# Altium schematic sheet StepGenerator.SchDoc(StepGenerator)
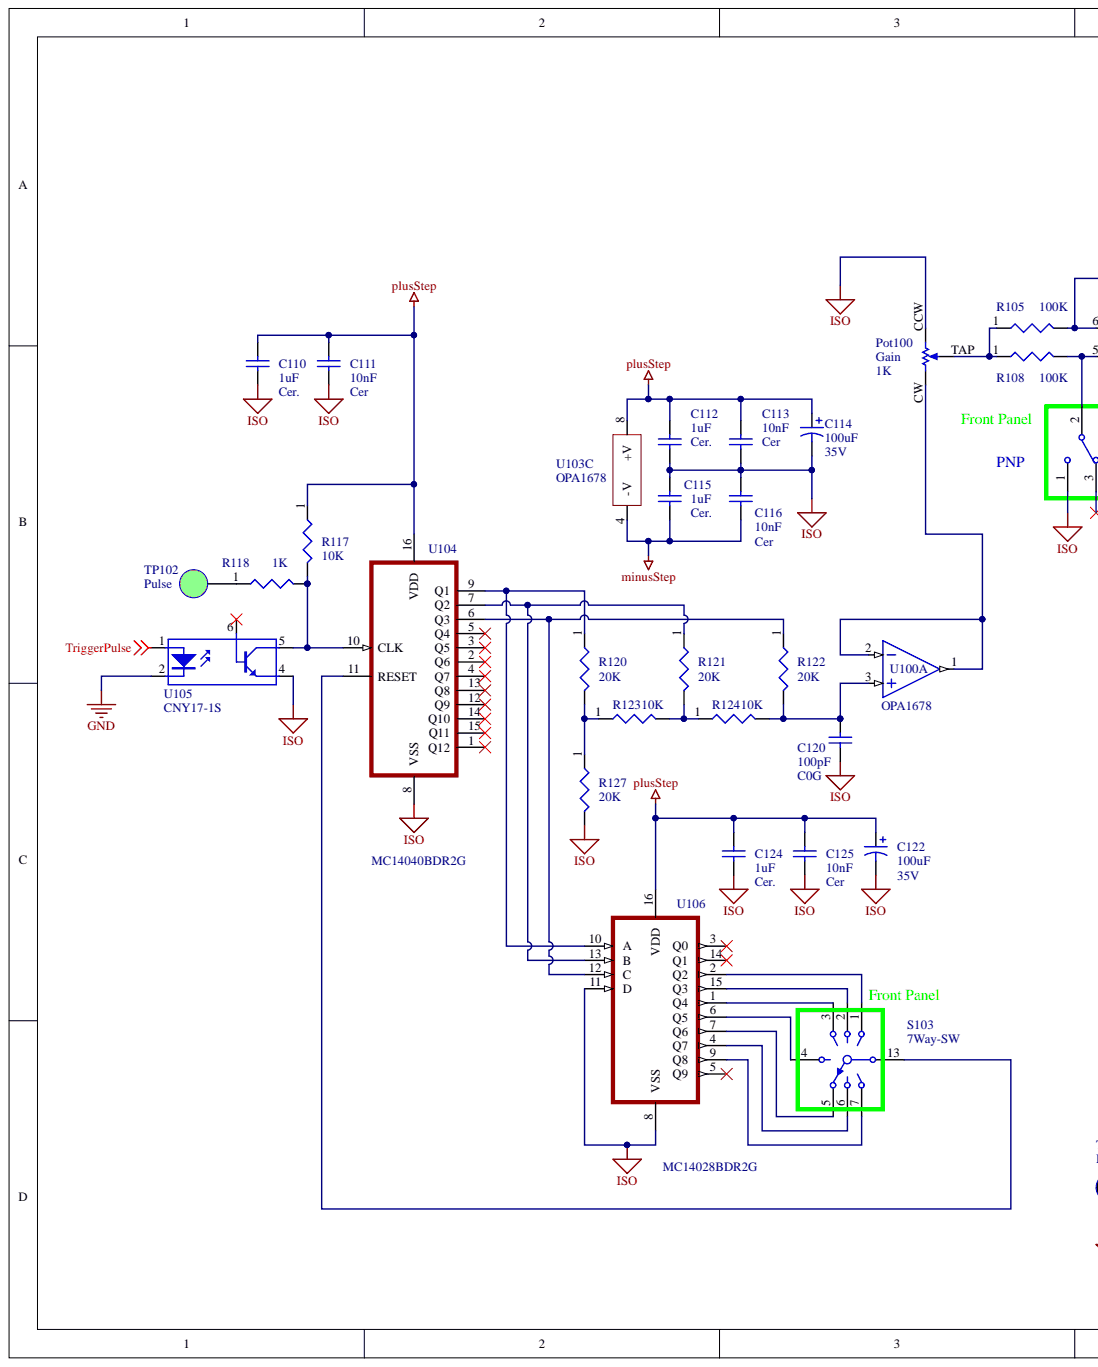
[diagram p0: StepGenerator.SchDoc, left]
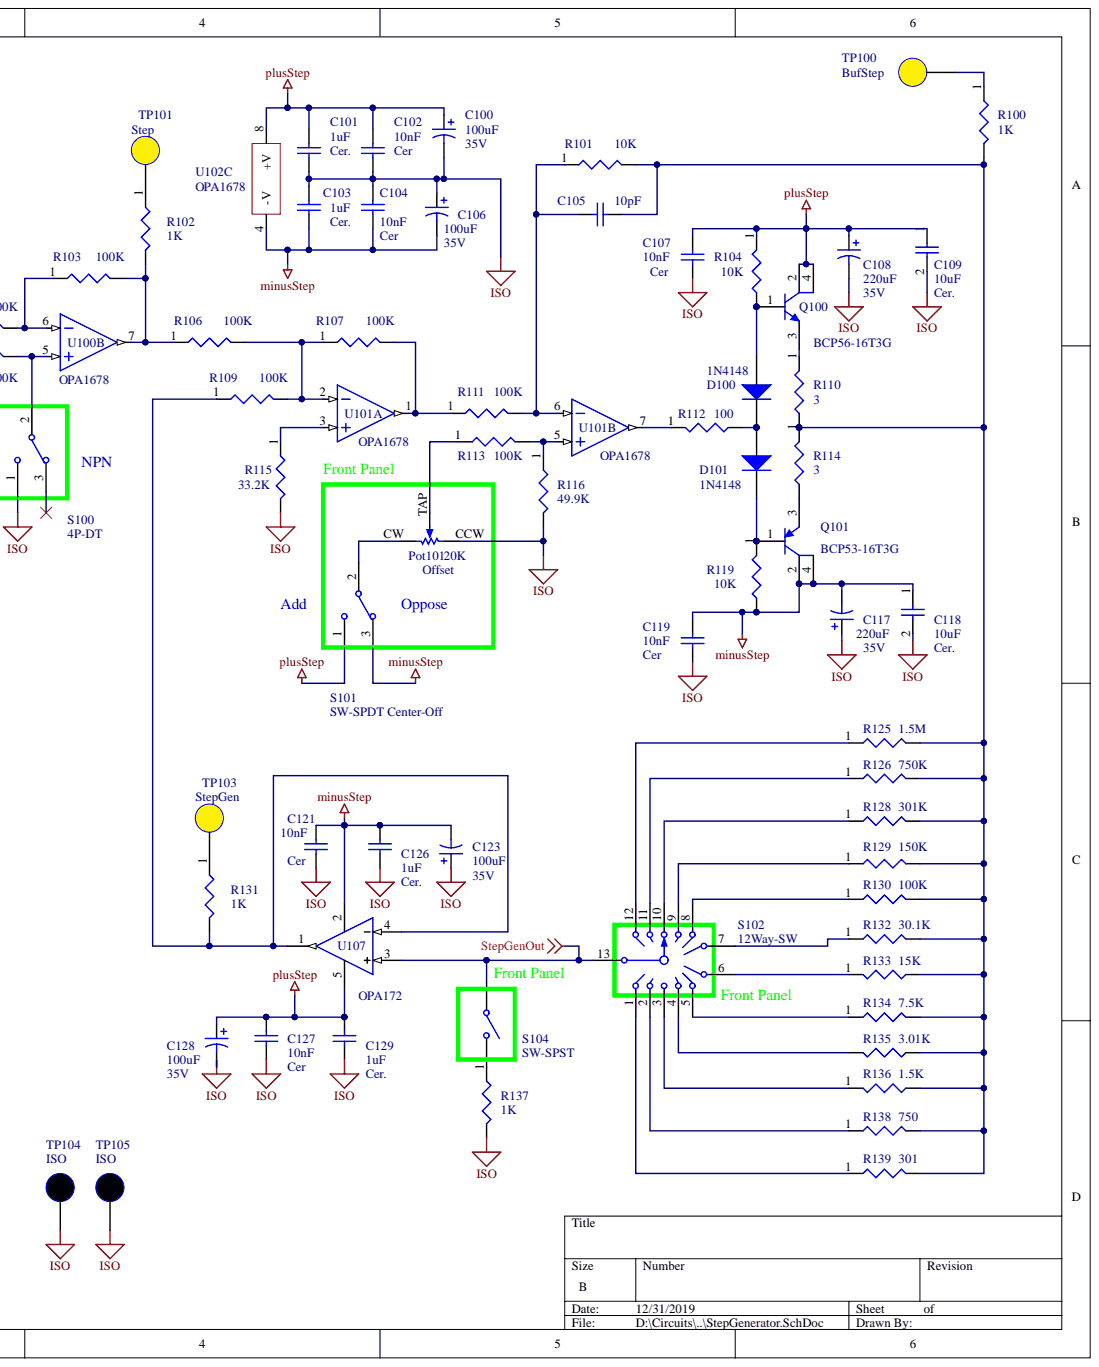
[diagram p1: StepGenerator.SchDoc, right]

## Parts
C100  100uF  Voltage Rating: 35V  [CAPPR2.5-6.3X10]
C101  value 1uF  Dielectric Material: Cer.  [CAPC3216M]  (hidden comment: Cap)
C102  10nF  Dielectric Material: Cer  [CAPC3216M]
C103  value 1uF  Dielectric Material: Cer.  [CAPC3216M]  (hidden comment: Cap)
C104  10nF  Dielectric Material: Cer  [CAPC3216M]
C105  value 10pF  [CAPC3216M]  (hidden comment: Cap)
C106  100uF  Voltage Rating: 35V  [CAPPR2.5-6.3X10]
C107  10nF  Dielectric Material: Cer  [CAPC3216M]
C108  220uF  Voltage Rating: 35V  [CAPPR3.5-8x12]
C109  value 10uF  Dielectric Material: Cer.  [CAPC3216M]  (hidden comment: Cap)
C110  value 1uF  Dielectric Material: Cer.  [CAPC3216M]  (hidden comment: Cap)
C111  10nF  Dielectric Material: Cer  [CAPC3216M]
C112  value 1uF  Dielectric Material: Cer.  [CAPC3216M]  (hidden comment: Cap)
C113  10nF  Dielectric Material: Cer  [CAPC3216M]
C114  100uF  Voltage Rating: 35V  [CAPPR2.5-6.3X10]
C115  value 1uF  Dielectric Material: Cer.  [CAPC3216M]  (hidden comment: Cap)
C116  10nF  Dielectric Material: Cer  [CAPC3216M]
C117  220uF  Voltage Rating: 35V  [CAPPR3.5-8x12]
C118  value 10uF  Dielectric Material: Cer.  [CAPC3216M]  (hidden comment: Cap)
C119  10nF  Dielectric Material: Cer  [CAPC3216M]
C120  value 100pF  Temperature Coefficient: C0G  [CAPC3216M]  (hidden comment: Cap)
C121  10nF  Dielectric Material: Cer  [CAPC3216M]
C122  100uF  Voltage Rating: 35V  [CAPPR2.5-6.3X10]
C123  100uF  Voltage Rating: 35V  [CAPPR2.5-6.3X10]
C124  value 1uF  Dielectric Material: Cer.  [CAPC3216M]  (hidden comment: Cap)
C125  10nF  Dielectric Material: Cer  [CAPC3216M]
C126  value 1uF  Dielectric Material: Cer.  [CAPC3216M]  (hidden comment: Cap)
C127  10nF  Dielectric Material: Cer  [CAPC3216M]
C128  100uF  Voltage Rating: 35V  [CAPPR2.5-6.3X10]
C129  value 1uF  Dielectric Material: Cer.  [CAPC3216M]  (hidden comment: Cap)
D100  1N4148  [SOD123]
D101  1N4148  [SOD123]
Pot100  Gain  value 1K  [3306P-CCW]
Pot101  Offset  value 20K  [Off-PCB]
Q100  Manufacturer Part Number: BCP56-16T3G  [SOT223-4M]  (hidden comment: NPN-2C)
Q101  Manufacturer Part Number: BCP53-16T3G  [SOT223-4M]  (hidden comment: PNP-2C)
R100  1K  [RESC3216M]
R101  value 10K  [RESC3216M]  (hidden comment: 20K)
R102  1K  [RESC3216M]
R103  value 100K  [RESC3216M]  (hidden comment: 20K)
R104  10K  [RESC3216M]
R105  100k  [RESC3216M]
R106  100k  [RESC3216M]
R107  100k  [RESC3216M]
R108  100k  [RESC3216M]
R109  100k  [RESC3216M]
R110  value 3  [RESC3216M]  (hidden comment: 100)
R111  100k  [RESC3216M]
R112  100  [RESC3216M]
R113  100k  [RESC3216M]
R114  value 3  [RESC3216M]  (hidden comment: 100)
R115  value 33.2K  [RESC3216M]  (hidden comment: 3.32KXXK)
R116  49.9K  [RESC3216M]
R117  value 10K  [RESC3216M]  (hidden comment: 20K)
R118  1K  [RESC3216M]
R119  10K  [RESC3216M]
R120  20K  [RESC3216M]
R121  20K  [RESC3216M]
R122  20K  [RESC3216M]
R123  20K  value 10K  [RESC3216M]
R124  20K  value 10K  [RESC3216M]
R125  1.5M  [RESC3216M]
R126  750K  [RESC3216M]
R127  20K  [RESC3216M]
R128  301K  [RESC3216M]
R129  150K  [RESC3216M]
R130  value 100K  [RESC3216M]  (hidden comment: 75K)
R131  1K  [RESC3216M]
R132  30.1K  [RESC3216M]
R133  15K  [RESC3216M]
R134  7.5K  [RESC3216M]
R135  value 3.01K  [RESC3216M]  (hidden comment: 3K)
R136  1.5K  [RESC3216M]
R137  1K  [RESC3216M]
R138  750  [RESC6432M]
R139  301  [RESC6432M]
S100  4P-DT  [3-2.5MMLS1-3]
S101  SW-SPDT Center-Off  [3-2.5MMLS]
S102  12Way-SW  [GrayHill-71-12]
S103  7Way-SW  [GrayHill-71-10]
S104  SW-SPST  [2-2.5MMLS]
TP100  BufStep  [TP-.038]
TP101  Step  [TP-.038]
TP102  Pulse  [TP-.038]
TP103  StepGen  [TP-.038]
TP104  ISO  [TP-.038]
TP105  ISO  [TP-.038]
U100  OPA1678  [SOIC127P600X168_HS-8N]  (parts A,B)
U101  OPA1678  [SOIC127P600X168_HS-8N]  (parts A,B)
U102  OPA1678  [SOIC127P600X168_HS-8N]  (part C)
U103  OPA1678  [SOIC127P600X168_HS-8N]  (part C)
U104  MC14040BDR2G  [D16-M]
U105  CNY-17-1S  value CNY17-1S  [SOIC127P1090X6]
U106  MC14028BDR2G  [D16-M]
U107  OPA172  [SOT23-5AM]

## Nets
GND: U105.2
ISO: C100.2 C101.1 C102.1 C103.2 C104.2 C106.1 C107.1 C108.2 C109.2 C110.1 C111.1 C112.1 C113.1 C114.2 C115.2 C116.2 C117.1 C118.2 C119.1 C120.2 C121.1 C122.2 C123.1 C124.1 C125.1 C126.1 C127.1 C128.2 C129.1 Pot100.CCW Pot101.CCW R115.2 R116.2 R127.2 R137.2 S100.1 TP104.1 TP105.1 U104.8(VSS) U105.4 U106.8(VSS) U106.11(D)
minusStep: C103.1 C104.1 C106.2 C115.1 C116.1 C117.2 C118.1 C119.2 C121.2 C123.2 C126.2 Q101.2 Q101.4 R119.2 S101.3 U102.4(-V) U103.4(-V) U107.2
plusStep: C100.1 C101.2 C102.2 C107.2 C108.1 C109.1 C110.2 C111.2 C112.2 C113.2 C114.1 C122.1 C124.2 C125.2 C127.2 C128.1 C129.2 Q100.2 Q100.4 R104.1 R117.1 S101.1 U102.8(+V) U103.8(+V) U104.16(VDD) U106.16(VDD) U107.5
StepGenOut: S102.13 S104.1 U107.3
TriggerPulse: U105.1
NetC105_1: C105.1 R100.2 R101.2 R110.2 R114.1 R125.2 R126.2 R128.2 R129.2 R130.2 R132.2 R133.2 R134.2 R135.1 R136.2 R138.2 R139.2
NetC105_2: C105.2 R101.1 R111.2 U101.6
NetC120_1: C120.1 R122.2 R124.2 U100.3
NetD100_1: D100.1 Q100.1 R104.2
NetD100_2: D100.2 D101.1 R112.2
NetD101_2: D101.2 Q101.1 R119.1
NetPot100_CW: Pot100.CW U100.1 U100.2
NetPot100_TAP: Pot100.TAP R105.1 R108.1
NetPot101_CW: Pot101.CW S101.2
NetPot101_TAP: Pot101.TAP R113.1
NetQ100_3: Q100.3 R110.1
NetQ101_3: Q101.3 R114.2
NetR100_1: R100.1 TP100.1
NetR102_1: R102.1 TP101.1
NetR102_2: R102.2 R103.2 R106.1 U100.7
NetR103_1: R103.1 R105.2 U100.6
NetR106_2: R106.2 R107.1 R109.2 U101.2
NetR107_2: R107.2 R111.1 U101.1
NetR108_2: R108.2 S100.2 U100.5
NetR109_1: R109.1 R131.2 U107.1 U107.4
NetR112_1: R112.1 U101.7
NetR113_2: R113.2 R116.1 U101.5
NetR115_1: R115.1 U101.3
NetR117_2: R117.2 R118.2 U104.10(CLK) U105.5
NetR118_1: R118.1 TP102.1
NetR120_1: R120.1 U104.9(Q1) U106.10(A)
NetR120_2: R120.2 R123.1 R127.1
NetR121_1: R121.1 U104.7(Q2) U106.13(B)
NetR121_2: R121.2 R123.2 R124.1
NetR122_1: R122.1 U104.6(Q3) U106.12(C)
NetR125_1: R125.1 S102.12
NetR126_1: R126.1 S102.11
NetR128_1: R128.1 S102.10
NetR129_1: R129.1 S102.9
NetR130_1: R130.1 S102.8
NetR131_1: R131.1 TP103.1
NetR132_1: R132.1 S102.7
NetR133_1: R133.1 S102.6
NetR134_1: R134.1 S102.5
NetR135_2: R135.2 S102.4
NetR136_1: R136.1 S102.3
NetR137_1: R137.1 S104.2
NetR138_1: R138.1 S102.2
NetR139_1: R139.1 S102.1
NetS103_1: S103.1 U106.2(Q2)
NetS103_2: S103.2 U106.15(Q3)
NetS103_3: S103.3 U106.1(Q4)
NetS103_4: S103.4 U106.6(Q5)
NetS103_5: S103.5 U106.7(Q6)
NetS103_6: S103.6 U106.4(Q7)
NetS103_7: S103.7 U106.9(Q8)
NetS103_13: S103.13 U104.11(RESET)

Not connected: S100 3; U104 1(Q12) 2(Q6) 3(Q5) 4(Q7) 5(Q4) 12(Q9) 13(Q8) 14(Q10) 15(Q11); U105 6; U106 3(Q0) 5(Q9) 14(Q1)

## Library data of the parts
C100 C106 C114 C122 C123 C128 = Cap Pol1: CAP ALUM 100UF 20% 35V THRU HOLE; Manufacturer Part Number: 35YXJ100M6.3X11; Manufacturer: Rubycon; Ripple Current: 400mA; Polarity: Polar; Life (Hours): 7000Hour; Leakage Current: 3uA; Lead Diameter: 0.5mm; Impedance: 220mR; Voltage: 35V; Value: 100uF; Tolerance: 20%; Termination: Radial; Supplier Part Number: 1189-2260-ND; Supplier: Digi-Key; Simulation: CAP; Signal Integrity: Cap Pol; Radiation Hardening: No; Packaging: Bulk; Package Quantity: 3000; Mount: Through Hole; Min Operating Temperature: -40°C; Max Operating Temperature: 105°C; Library Name: Miscellaneous Devices.IntLib; Lead Pitch: 2.5mm; Height - Seated (Max): 0.492inch; Height: 11mm; Diameter: 6.3mm; Component Kind: Standard; Case/Package: Radial; Capacitance: 100uF
C101 C103 C110 C112 C115 C124 C126 C129 = Cap: CAP CER 1UF 25V X7R 1206; Manufacturer Part Number: C1206C105M3RACTU; Manufacturer: Kemet; Tolerance: ±20%; Capacitance: 1µF; Voltage - Rated: 25V; Thickness (Max): 0.055" (1.40mm); Temperature Coefficient: X7R; Supplier Part Number: 399-8148-1-ND; Supplier: Digi-Key; Size / Dimension: 0.126" L x 0.063" W (3.20mm x 1.60mm); Simulation: CAP; Signal Integrity: Cap; RoHS: RoHS Compliant; Packaging: Cut Tape (CT); Package / Case: 1206 (3216 Metric); Operating Temperature: -55°C ~ 125°C; Mounting Type: Surface Mount, MLCC; Library Name: Miscellaneous Devices.IntLib; Component Kind: Standard; Category: Capacitors; Applications: General Purpose
C102 C104 C107 C111 C113 C116 C119 C121 C125 C127 = Cap: CAP CER 1UF 25V X7R 1206; Manufacturer Part Number: C1206C103K3RACTU; Manufacturer: KEMET; Tolerance: 10%; Width: 1.6mm; Voltage Rating (DC): 25V; Voltage Rating: 25V; Value: 10nF; Thickness: 0.035inch; Termination: SMD/SMT; Supplier Part Number: 399-14644-1-ND; Supplier: Digi-Key; Simulation: CAP; Signal Integrity: Cap; Radiation Hardening: No; Packaging: Tape and Reel; Package Quantity: 4000; Mount: Surface Mount; Min Operating Temperature: -55°C; Max Operating Temperature: 125°C; Library Name: Miscellaneous Devices.IntLib; Length: 3.2mm; Height: 0.78mm; Dielectric: X7R; Depth: 1.6mm; Component Kind: Standard; Case Code (Metric): 3216; Case Code (Imperial): 1206; Case/Package: 1206; Capacitance: 10nF
C105 = Cap: CAP CER 10PF 50V C0G/NP0 0805; Manufacturer Part Number: C0805C100J5GACTU; Manufacturer: KEMET; Tolerance: ±5%; Temperature Coefficient: NP0; Voltage - Rated: 50V; Thickness (Max): 0.035" (0.88mm); Supplier Part Number: 399-1108-1-ND; Supplier: Digi-Key; Size / Dimension: 0.079" L x 0.049" W (2.00mm x 1.25mm); Simulation: CAP; Signal Integrity: Cap; RoHS: RoHS Compliant; Part Status: Active; Packaging: Cut Tape (CT); Package / Case: 0805 (2012 Metric); Operating Temperature: -55°C ~ 125°C; Mounting Type: Surface Mount, MLCC; Library Name: Miscellaneous Devices.IntLib; Features: Low ESL; Component Kind: Standard; Category: Capacitors; Capacitance: 10pF; Applications: General Purpose
C108 C117 = Cap Pol1: CAP ALUM 220UF 20% 35V RADIAL; Manufacturer Part Number: 860020574012; Manufacturer: Wurth Electronics; Ripple Current: 255mA; Polarity: Polar; Life (Hours): 2000Hour; Value: 220uF; Tolerance: 20%; Supplier Part Number: 732-8946-1-ND; Supplier: Digi-Key; Simulation: CAP; Signal Integrity: Cap Pol; Packaging: Tape and Reel; Package Quantity: 800; Mount: Through Hole; Min Operating Temperature: -40°C; Max Operating Temperature: 105°C; Library Name: My_Miscellaneous Devices.SchLib; Lead Pitch: 0.138inch; Height - Seated (Max): 0.512inch; Component Kind: Standard; Case/Package: Radial; Capacitance: 220uF
C109 C118 = Cap: CAP CER 10UF 25V X7R 1206; Manufacturer Part Number: TMK316B7106KL-TD; Manufacturer: Taiyo Yuden; Tolerance: ±10%; Capacitance: 10µF; Voltage - Rated: 25V; Thickness (Max): 0.071" (1.80mm); Temperature Coefficient: X7R; Supplier Part Number: 587-2399-1-ND; Supplier: Digi-Key; Size / Dimension: 0.126" L x 0.063" W (3.20mm x 1.60mm); Simulation: CAP; Signal Integrity: Cap; RoHS: RoHS Compliant; Part Status: Active; Packaging: Cut Tape (CT); Package / Case: 1206 (3216 Metric); Operating Temperature: -55°C ~ 125°C; Mounting Type: Surface Mount, MLCC; Library Name: Miscellaneous Devices.IntLib; Component Kind: Standard; Category: Capacitors; Applications: General Purpose
C120 = Cap: CAP CER 100PF 100V NP0 1206; Manufacturer Part Number: C1206C101J1GACTU; Manufacturer: Kemet; Tolerance: ±5%; Voltage - Rated: 100V; Thickness (Max): 0.035" (0.88mm); Supplier Part Number: 399-1206-1-ND; Supplier: Digi-Key; Size / Dimension: 0.126" L x 0.063" W (3.20mm x 1.60mm); Simulation: CAP; Signal Integrity: Cap; RoHS: RoHS Compliant; Packaging: Cut Tape (CT); Package / Case: 1206 (3216 Metric); Operating Temperature: -55°C ~ 125°C; Mounting Type: Surface Mount, MLCC; Library Name: Miscellaneous Devices.IntLib; Features: Low ESL; Component Kind: Standard; Category: Capacitors; Capacitance: 100pF; Applications: General Purpose
D100 D101 = Diode 1N4148: DIODE GP 75V 150MA SOD123FL; Manufacturer Part Number: 1N4148WFL-G3-08; Manufacturer: Vishay Semiconductor Diodes Division; Voltage - Forward (Vf) (Max) @ If: 1.2V @ 100mA; Speed: Small Signal =< 200mA (Io), Any Speed; Reverse Recovery Time (trr): 4ns; Diode Type: Standard; Current - Reverse Leakage @ Vr: 5µA @ 75V; Voltage - DC Reverse (Vr) (Max): 75V; Supplier Part Number: 1N4148WFL-G3-08GICT-ND; Supplier Device Package: SOD-123FL; Supplier: Digi-Key; Simulation: 1N4148; Signal Integrity: 1N4148; RoHS: RoHS Compliant; Published: 8-Jun-2000; Packaging: Cut Tape (CT); PackageReference: DO-35; PackageDescription: Diode, Axial; Body 3.8 x 1.9 mm (LxDia. max), Lead Dia. 0.53 mm (max); Package / Case: SOD-123F; Operating Temperature - Junction: 150°C (Max); Mounting Type: Surface Mount; Library Name: Miscellaneous Devices.IntLib; LatestRevisionNote: Re-released for DXP Platform.; LatestRevisionDate: 17-Jul-2002; DatasheetVersion: 1997; Current - Average Rectified (Io): 150mA; ComponentLink2URL: http://www.fairchildsemi.com/ds/1N%2F1N4148.pdf; ComponentLink2Description: Datasheet; ComponentLink1URL: http://www.fairchildsemi.com/; ComponentLink1Description: Manufacturer Link; Component Kind: Standard; Code_JEDEC: DO-204-AH; Category: Discrete Semiconductor Products; Publisher: Altium Limited
Pot100 Pot101 = POT-Single: TRIMMER 1K OHM 0.5W PC PIN TOP; Manufacturer Part Number: PV36W102C01B00; Manufacturer: Bourns; Tolerance: 10%; Temperature Coefficient: 100ppm/°C; Resistance: 1kR; Number of Turns: 25; Max Power Dissipation: 500mW; Termination: PC Pins; Supplier Part Number: 490-2874-ND; Supplier: Digi-Key; Packaging: Bulk; Mount: Through Hole; Height: 0.394inch; Composition: Cermet; Component Kind: Standard; Library Name: MY_POTS.SchLib
Q100 = NPN-2C: TRANS NPN 80V 1A SOT-223; Manufacturer: ON Semiconductor; Vce Saturation (Max) @ Ib, Ic: 500mV @ 50mA, 500mA; Power - Max: 1.5W; Frequency - Transition: 130MHz; DC Current Gain (hFE) (Min) @ Ic, Vce: 100 @ 150mA, 2V; Current - Collector Cutoff (Max): 100nA (ICBO); Voltage - Collector Emitter Breakdown (Max): 80V; Transistor Type: NPN; Supplier Part Number: BCP56-16T3GOSCT-ND; Supplier Device Package: SOT-223; Supplier: Digi-Key; RoHS: RoHS Compliant; Part Status: Active; Packaging: Cut Tape (CT); Package / Case: TO-261-4, TO-261AA; Operating Temperature: -65°C ~ 150°C (TJ); Mounting Type: Surface Mount; Library Name: My_Miscellaneous Devices.SchLib; Current - Collector (Ic) (Max): 1A; Component Kind: Standard; Category: Discrete Semiconductor Products
Q101 = PNP-2C: TRANS PNP 80V 1.5A SOT-223; Manufacturer: ON Semiconductor; Vce Saturation (Max) @ Ib, Ic: 500mV @ 50mA, 500mA; Power - Max: 1.5W; Frequency - Transition: 50MHz; DC Current Gain (hFE) (Min) @ Ic, Vce: 100 @ 150mA, 2V; Current - Collector Cutoff (Max): 100nA (ICBO); Voltage - Collector Emitter Breakdown (Max): 80V; Transistor Type: PNP; Supplier Part Number: BCP53-16T3GOSCT-ND; Supplier Device Package: SOT-223; Supplier: Digi-Key; RoHS: RoHS Compliant; Part Status: Active; Packaging: Cut Tape (CT); Package / Case: TO-261-4, TO-261AA; Operating Temperature: -65°C ~ 150°C (TJ); Mounting Type: Surface Mount; Library Name: My_Miscellaneous Devices.SchLib; Current - Collector (Ic) (Max): 1.5A; Component Kind: Standard; Category: Discrete Semiconductor Products
R100 R102 R118 R131 R137 = Res3: RES 1K OHM 1% 1/4W 1206; Manufacturer Part Number: RMCF1206FT1K00; Manufacturer: Stackpole Electronics; Temperature Coefficient: 100ppm/°C; Resistance: 1kR; Power Rating: 250mW; Width: 0.063inch; Value: 1K; Tolerance: 1%; Supplier Part Number: RMCF1206FT1K00CT-ND; Supplier: Arrow; Simulation: RESISTOR; Signal Integrity: Res; Radiation Hardening: No; Packaging: Tape and Reel; Number of Terminations: 2; Mount: Surface Mount; Min Operating Temperature: -55°C; Military Standard: Not; Max Power Dissipation: 250mW; Max Operating Temperature: 155°C; Library Name: Miscellaneous Devices.IntLib; Length: 3.2mm; Lead Free: Lead Free; Height: 0.028inch; Features: Automotive AEC-Q200; Depth: 1.6mm; Contact Plating: Matte,Tin; Composition: Thick Film; Component Kind: Standard; Case Code (Metric): 3216; Case Code (Imperial): 1206; Case/Package: 1206
R101 R103 R117 R120-R124 R127 = Res3: RES 20K OHM 1% 1/4W 1206; Manufacturer Part Number: RMCF1206FT20K0; Manufacturer: Stackpole Electronics; Temperature Coefficient: 100ppm/°C; Resistance: 20kR; Max Power Dissipation: 250mW; Width: 0.063inch; Tolerance: 1%; Supplier Part Number: RMCF1206FT20K0CT-ND; Supplier: Digi-Key; Simulation: RESISTOR; Signal Integrity: Res; Radiation Hardening: No; Packaging: Tape and Reel; Number of Terminations: 2; Mount: Surface Mount; Min Operating Temperature: -55°C; Military Standard: Not; Max Operating Temperature: 155°C; Library Name: Miscellaneous Devices.IntLib; Length: 3.2mm; Lead Free: Lead Free; Height: 0.028inch; Features: Automotive AEC-Q200; Depth: 1.6mm; Contact Plating: Matte,Tin; Composition: Thick Film; Component Kind: Standard; Case Code (Metric): 3216; Case Code (Imperial): 1206; Case/Package: 1206
  R120-R122 R127: Value: 20K
R104 R119 = Res3: RES 10K OHM 1% 1/4W 1206; Manufacturer Part Number: RMCF1206FT10K0; Manufacturer: Stackpole Electronics; Voltage Rating (DC): 200V; Temperature Coefficient: 100ppm/°C; Resistance: 10kR; Power Rating: 250mW; Width: 0.063inch; Voltage Rating: 200V; Value: 10K; Tolerance: 1%; Termination: SMD/SMT; Supplier Part Number: RMCF1206FT10K0CT-ND; Supplier: Digi-Key; Simulation: RESISTOR; Signal Integrity: Res; REACH SVHC: No SVHC; Radiation Hardening: No; Packaging: Tape and Reel; Package Quantity: 1; Number of Terminations: 2; Number of Pins: 2; Mount: Surface Mount; Min Operating Temperature: -55°C; Military Standard: Not; Max Power Dissipation: 250mW; Max Operating Temperature: 155°C; Library Name: Miscellaneous Devices.IntLib; Length: 3.2mm; Lead Free: Lead Free; Height: 0.028inch; Features: Automotive AEC-Q200; Depth: 1.6mm; Contact Plating: Matte,Tin; Composition: Thick Film; Component Kind: Standard; Case Code (Metric): 3216; Case Code (Imperial): 1206; Case/Package: 1206
R105-R109 R111 R113 = Res3: RES 100K OHM 1% 1/4W 1206; Manufacturer Part Number: RMCF1206FT100K; Manufacturer: Stackpole Electronics; Temperature Coefficient: 100ppm/°C; Resistance: 100kR; Power Rating: 250mW; Width: 0.063inch; Value: 100K; Tolerance: 1%; Supplier Part Number: RMCF1206FT100KCT-ND; Supplier: Digi-Key; Simulation: RESISTOR; Signal Integrity: Res; Radiation Hardening: No; Packaging: Tape and Reel; Number of Terminations: 2; Mount: Surface Mount; Min Operating Temperature: -55°C; Military Standard: Not; Max Power Dissipation: 250mW; Max Operating Temperature: 155°C; Library Name: Miscellaneous Devices.IntLib; Length: 0.126inch; Lead Free: Lead Free; Height: 0.028inch; Features: Automotive AEC-Q200; Depth: 1.6mm; Contact Plating: Matte,Tin; Composition: Thick Film; Component Kind: Standard; Case Code (Metric): 3216; Case Code (Imperial): 1206; Case/Package: 1206
R110 R112 R114 = Res3: RES 100 OHM 1% 1/4W 1206; Manufacturer Part Number: RMCF1206FT100R; Manufacturer: Stackpole Electronics; Temperature Coefficient: 100ppm/°C; Resistance: 100R; Power Rating: 250mW; Width: 0.063inch; Tolerance: 1%; Supplier Part Number: RMCF1206FT100RCT-ND; Supplier: Digi-Key; Simulation: RESISTOR; Signal Integrity: Res; Radiation Hardening: No; Packaging: Tape and Reel; Number of Terminations: 2; Mount: Surface Mount; Min Operating Temperature: -55°C; Military Standard: Not; Max Power Dissipation: 250mW; Max Operating Temperature: 155°C; Library Name: Miscellaneous Devices.IntLib; Length: 0.126inch; Lead Free: Lead Free; Height: 0.028inch; Features: Automotive AEC-Q200; Depth: 1.6mm; Contact Plating: Matte,Tin; Composition: Thick Film; Component Kind: Standard; Case Code (Metric): 3216; Case Code (Imperial): 1206; Case/Package: 1206
  R112: Value: 100
R115 = Res3: RES 3.32K OHM 1% 1/4W 1206; Manufacturer Part Number: RMCF1206FT3K32; Manufacturer: Stackpole Electronics; Temperature Coefficient: 100ppm/°C; Resistance: 3.32kR; Power Rating: 250mW; Width: 0.063inch; Tolerance: 1%; Supplier Part Number: RMCF1206FT3K32CT-ND; Supplier: Digi-Key; Simulation: RESISTOR; Signal Integrity: Res; Radiation Hardening: No; Packaging: Tape and Reel; Number of Terminations: 2; Mount: Surface Mount; Min Operating Temperature: -55°C; Military Standard: Not; Max Power Dissipation: 250mW; Max Operating Temperature: 155°C; Library Name: Miscellaneous Devices.IntLib; Length: 0.126inch; Lead Free: Lead Free; Height: 0.028inch; Features: Automotive AEC-Q200; Depth: 1.6mm; Contact Plating: Matte,Tin; Composition: Thick Film; Component Kind: Standard; Case Code (Metric): 3216; Case Code (Imperial): 1206; Case/Package: 1206
R116 = Res3: RES 49.9K OHM 1% 1/4W 1206; Manufacturer Part Number: RMCF1206FT49K9; Manufacturer: Stackpole Electronics; Temperature Coefficient: 100ppm/°C; Resistance: 49.9kR; Power Rating: 250mW; Width: 0.063inch; Value: 49.9K; Tolerance: 1%; Supplier Part Number: RMCF1206FT49K9CT-ND; Supplier: Digi-Key; Simulation: RESISTOR; Signal Integrity: Res; Radiation Hardening: No; Packaging: Tape and Reel; Number of Terminations: 2; Mount: Surface Mount; Min Operating Temperature: -55°C; Military Standard: Not; Max Power Dissipation: 250mW; Max Operating Temperature: 155°C; Library Name: Miscellaneous Devices.IntLib; Length: 0.126inch; Lead Free: Lead Free; Height: 0.028inch; Features: Automotive AEC-Q200; Depth: 1.6mm; Contact Plating: Matte,Tin; Composition: Thick Film; Component Kind: Standard; Case Code (Metric): 3216; Case Code (Imperial): 1206; Case/Package: 1206
R125 = Res3: RES 1.5M OHM 1% 1/4W 1206; Manufacturer Part Number: RMCF1206FT1M50; Manufacturer: Stackpole Electronics; Temperature Coefficient: 100ppm/°C; Resistance: 1.5MR; Power Rating: 250mW; Width: 0.063inch; Value: 1.5M; Tolerance: 1%; Supplier Part Number: RMCF1206FT1M50CT-ND; Supplier: Digi-Key; Simulation: RESISTOR; Signal Integrity: Res; Radiation Hardening: No; Packaging: Tape and Reel; Number of Terminations: 2; Mount: Surface Mount; Min Operating Temperature: -55°C; Military Standard: Not; Max Power Dissipation: 250mW; Max Operating Temperature: 155°C; Library Name: Miscellaneous Devices.IntLib; Length: 0.126inch; Lead Free: Lead Free; Height: 0.028inch; Features: Automotive AEC-Q200; Depth: 1.6mm; Contact Plating: Matte,Tin; Composition: Thick Film; Component Kind: Standard; Case Code (Metric): 3216; Case Code (Imperial): 1206; Case/Package: 1206
R126 = Res3: RES 750K OHM 1% 1/4W 1206; Manufacturer Part Number: RMCF1206FT750K; Manufacturer: Stackpole Electronics; Temperature Coefficient: 100ppm/°C; Resistance: 750kR; Power Rating: 250mW; Width: 0.063inch; Value: 750K; Tolerance: 1%; Supplier Part Number: RMCF1206FT750KCT-ND; Supplier: Digi-Key; Simulation: RESISTOR; Signal Integrity: Res; Radiation Hardening: No; Packaging: Tape and Reel; Number of Terminations: 2; Mount: Surface Mount; Min Operating Temperature: -55°C; Military Standard: Not; Max Power Dissipation: 250mW; Max Operating Temperature: 155°C; Library Name: Miscellaneous Devices.IntLib; Length: 0.126inch; Lead Free: Lead Free; Height: 0.028inch; Features: Automotive AEC-Q200; Depth: 1.6mm; Contact Plating: Tin,Matte; Composition: Thick Film; Component Kind: Standard; Case Code (Metric): 3216; Case Code (Imperial): 1206; Case/Package: 1206
R128 = Res3: RES 301K OHM 1% 1/4W 1206; Manufacturer Part Number: RMCF1206FT301K; Manufacturer: Stackpole Electronics; Temperature Coefficient: 100ppm/°C; Resistance: 301kR; Power Rating: 250mW; Width: 0.063inch; Value: 301K; Tolerance: 1%; Supplier Part Number: RMCF1206FT301KCT-ND; Supplier: Digi-Key; Simulation: RESISTOR; Signal Integrity: Res; Radiation Hardening: No; Packaging: Tape and Reel; Number of Terminations: 2; Mount: Surface Mount; Min Operating Temperature: -55°C; Military Standard: Not; Max Power Dissipation: 250mW; Max Operating Temperature: 155°C; Library Name: Miscellaneous Devices.IntLib; Length: 0.126inch; Lead Free: Lead Free; Height: 0.028inch; Features: Automotive AEC-Q200; Depth: 1.6mm; Contact Plating: Tin,Matte; Composition: Thick Film; Component Kind: Standard; Case Code (Metric): 3216; Case Code (Imperial): 1206; Case/Package: 1206
R129 = Res3: RES 150K OHM 1% 1/4W 1206; Manufacturer Part Number: RMCF1206FT150K; Manufacturer: Stackpole Electronics; Temperature Coefficient: 100ppm/°C; Resistance: 150kR; Power Rating: 250mW; Width: 0.063inch; Value: 150K; Tolerance: 1%; Supplier Part Number: RMCF1206FT150KCT-ND; Supplier: Digi-Key; Simulation: RESISTOR; Signal Integrity: Res; Radiation Hardening: No; Packaging: Tape and Reel; Number of Terminations: 2; Mount: Surface Mount; Min Operating Temperature: -55°C; Military Standard: Not; Max Power Dissipation: 250mW; Max Operating Temperature: 155°C; Library Name: Miscellaneous Devices.IntLib; Length: 0.126inch; Lead Free: Lead Free; Height: 0.028inch; Features: Automotive AEC-Q200; Depth: 1.6mm; Contact Plating: Matte,Tin; Composition: Thick Film; Component Kind: Standard; Case Code (Metric): 3216; Case Code (Imperial): 1206; Case/Package: 1206
R130 = Res3: RES 75K OHM 1% 1/4W 1206; Manufacturer Part Number: RMCF1206FT75K0; Manufacturer: Stackpole Electronics; Temperature Coefficient: 100ppm/°C; Resistance: 175KR; Power Rating: 250mW; Width: 0.063inch; Tolerance: 1%; Supplier Part Number: RMCF1206FT75K0CT-ND; Supplier: Digi-Key; Simulation: RESISTOR; Signal Integrity: Res; Radiation Hardening: No; Packaging: Tape and Reel; Number of Terminations: 2; Mount: Surface Mount; Min Operating Temperature: -55°C; Military Standard: Not; Max Power Dissipation: 250mW; Max Operating Temperature: 155°C; Library Name: Miscellaneous Devices.IntLib; Length: 0.126inch; Lead Free: Lead Free; Height: 0.028inch; Features: Automotive AEC-Q200; Depth: 1.6mm; Contact Plating: Matte,Tin; Composition: Thick Film; Component Kind: Standard; Case Code (Metric): 3216; Case Code (Imperial): 1206; Case/Package: 1206
R132 = Res3: RES 30.1K OHM 1% 1/4W 1206; Manufacturer Part Number: RMCF1206FT30K1; Manufacturer: Stackpole Electronics; Temperature Coefficient: 100ppm/°C; Resistance: 30.1kR; Power Rating: 250mW; Width: 0.063inch; Value: 30.1K; Tolerance: 1%; Supplier Part Number: RMCF1206FT30K0CT-ND; Supplier: Digi-Key; Simulation: RESISTOR; Signal Integrity: Res; Radiation Hardening: No; Packaging: Tape and Reel; Number of Terminations: 2; Mount: Surface Mount; Min Operating Temperature: -55°C; Military Standard: Not; Max Power Dissipation: 250mW; Max Operating Temperature: 155°C; Library Name: Miscellaneous Devices.IntLib; Length: 0.126inch; Lead Free: Lead Free; Height: 0.028inch; Features: Automotive AEC-Q200; Depth: 1.6mm; Contact Plating: Matte,Tin; Composition: Thick Film; Component Kind: Standard; Case Code (Metric): 3216; Case Code (Imperial): 1206; Case/Package: 1206
R133 = Res3: RES 15K OHM 1% 1/4W 1206; Manufacturer Part Number: RMCF1206FT15K0; Manufacturer: Stackpole Electronics; Voltage Rating (DC): 200V; Temperature Coefficient: 100ppm/°C; Resistance: 15kR; Power Rating: 250mW; Width: 0.063inch; Voltage Rating: 200V; Value: 15K; Tolerance: 1%; Termination: SMD/SMT; Supplier Part Number: RMCF1206FT15K0CT-ND; Supplier: Digi-Key; Simulation: RESISTOR; Signal Integrity: Res; REACH SVHC: No SVHC; Radiation Hardening: No; Packaging: Tape and Reel; Package Quantity: 1; Number of Terminations: 2; Number of Pins: 2; Mount: Surface Mount; Min Operating Temperature: -55°C; Military Standard: Not; Max Power Dissipation: 250mW; Max Operating Temperature: 155°C; Library Name: Miscellaneous Devices.IntLib; Length: 3.2mm; Lead Free: Lead Free; Height: 0.028inch; Features: Automotive AEC-Q200; Depth: 1.6mm; Contact Plating: Matte,Tin; Composition: Thick Film; Component Kind: Standard; Case Code (Metric): 3216; Case Code (Imperial): 1206; Case/Package: 1206
R134 = Res3: RES 7.50K OHM 1% 1/4W 1206; Manufacturer Part Number: RMCF1206FT7K50; Manufacturer: Stackpole Electronics; Temperature Coefficient: 100ppm/°C; Resistance: 7.5kR; Power Rating: 250mW; Width: 0.063inch; Value: 7.5K; Tolerance: 1%; Supplier Part Number: RMCF1206FT7K50CT-ND; Supplier: Digi-Key; Simulation: RESISTOR; Signal Integrity: Res; Radiation Hardening: No; Packaging: Tape and Reel; Number of Terminations: 2; Mount: Surface Mount; Min Operating Temperature: -55°C; Military Standard: Not; Max Power Dissipation: 250mW; Max Operating Temperature: 155°C; Library Name: Miscellaneous Devices.IntLib; Length: 0.126inch; Lead Free: Lead Free; Height: 0.028inch; Features: Automotive AEC-Q200; Depth: 1.6mm; Contact Plating: Matte,Tin; Composition: Thick Film; Component Kind: Standard; Case Code (Metric): 3216; Case Code (Imperial): 1206; Case/Package: 1206
R135 = Res3: RES  3.01K OHM 1% 1/4W 1206; Manufacturer Part Number: RMCF1206FT3K01; Manufacturer: Stackpole Electronics; Temperature Coefficient: 100ppm/°C; Resistance: 3.01kR; Power Rating: 250mW; Width: 0.063inch; Tolerance: 1%; Supplier Part Number: RMCF1206FT3K01CT-ND; Supplier: Digi-Key; Simulation: RESISTOR; Signal Integrity: Res; Radiation Hardening: No; Packaging: Tape and Reel; Number of Terminations: 2; Mount: Surface Mount; Min Operating Temperature: -55°C; Military Standard: Not; Max Power Dissipation: 250mW; Max Operating Temperature: 155°C; Library Name: Miscellaneous Devices.IntLib; Length: 0.126inch; Lead Free: Lead Free; Height: 0.028inch; Features: Automotive AEC-Q200; Depth: 1.6mm; Contact Plating: Matte,Tin; Composition: Thick Film; Component Kind: Standard; Case Code (Metric): 3216; Case Code (Imperial): 1206; Case/Package: 1206
R136 = Res3: RES 1.5K OHM 1% 1/4W 1206; Manufacturer Part Number: RMCF1206FT1K50; Manufacturer: Stackpole Electronics; Temperature Coefficient: 100ppm/°C; Resistance: 1.5KR; Power Rating: 250mW; Width: 0.063inch; Value: 1.5K; Tolerance: 1%; Supplier Part Number: RMCF1206FT1K50CT-ND; Supplier: Digi-Key; Simulation: RESISTOR; Signal Integrity: Res; Radiation Hardening: No; Packaging: Tape and Reel; Package Quantity: 1; Number of Terminations: 2; Mount: Surface Mount; Min Operating Temperature: -55°C; Military Standard: Not; Max Power Dissipation: 250mW; Max Operating Temperature: 155°C; Library Name: Miscellaneous Devices.IntLib; Length: 0.126inch; Lead Free: Lead Free; Height: 0.028inch; Features: Automotive AEC-Q200; Depth: 1.6mm; Contact Plating: Matte,Tin; Composition: Thick Film; Component Kind: Standard; Case Code (Metric): 3216; Case Code (Imperial): 1206; Case/Package: 1206
R138 = Res3: RES 750 OHM 1% 1/4W 1206; Manufacturer Part Number: RMCF1206FT750R; Manufacturer: Stackpole Electronics; Temperature Coefficient: 100ppm/°C; Resistance: 750R; Power Rating: 250mW; Width: 0.063inch; Value: 750; Tolerance: 1%; Supplier Part Number: RMCF1206FT750RCT-ND; Supplier: Digi-Key; Simulation: RESISTOR; Signal Integrity: Res; Radiation Hardening: No; Packaging: Tape and Reel; Number of Terminations: 2; Mount: Surface Mount; Min Operating Temperature: -55°C; Military Standard: Not; Max Power Dissipation: 250mW; Max Operating Temperature: 155°C; Library Name: Miscellaneous Devices.IntLib; Length: 0.126inch; Lead Free: Lead Free; Height: 0.028inch; Features: Automotive AEC-Q200; Depth: 1.6mm; Contact Plating: Tin,Matte; Composition: Thick Film; Component Kind: Standard; Case Code (Metric): 3216; Case Code (Imperial): 1206; Case/Package: 1206
R139 = Res3: RES 301 OHM 1% 1/4W 1206; Manufacturer Part Number: RMCF1206FT301R; Manufacturer: Stackpole Electronics; Temperature Coefficient: 100ppm/°C; Resistance: 301R; Power Rating: 250mW; Width: 0.063inch; Value: 301; Tolerance: 1%; Supplier Part Number: RMCF1206FT301RCT-ND; Supplier: Digi-Key; Simulation: RESISTOR; Signal Integrity: Res; Radiation Hardening: No; Packaging: Tape and Reel; Number of Terminations: 2; Mount: Surface Mount; Min Operating Temperature: -55°C; Military Standard: Not; Max Power Dissipation: 250mW; Max Operating Temperature: 155°C; Library Name: Miscellaneous Devices.IntLib; Length: 0.126inch; Lead Free: Lead Free; Height: 0.028inch; Features: Automotive AEC-Q200; Depth: 1.6mm; Contact Plating: Matte,Tin; Composition: Thick Film; Component Kind: Standard; Case Code (Metric): 3216; Case Code (Imperial): 1206; Case/Package: 1206
S100 S101 = SW-SPDT: SPDT Subminiature Toggle Switch, Right Angle Mounting, Vertical Actuation; Published: 24-Mar-1999; PackageReference: TL36WW15050; LatestRevisionNote: Schematic revised, PCB Footprint replaced.; LatestRevisionDate: 17-Dec-2003; DatasheetVersion: 05-Dec-2000; ComponentLink1URL: http://www.apem.com; ComponentLink1Description: Manufacturer Link; Component Kind: Standard; Publisher: Altium Limited
  S100: Library Name: My_Miscellaneous Devices.IntLib
  S101: PackageDescription: Switch; 4 Leads; Library Name: Miscellaneous Devices.IntLib
S102 = 12Way-SW: 12Way rotary Switch; Library Name: MY_SWITCH.SchLib; Component Kind: Standard
S104 = SW-SPST: Single-Pole, Single-Throw Switch; Published: 24-Mar-1999; PackageReference: SPST-2; PackageDescription: Switch; 2 Leads; Library Name: Miscellaneous Devices.IntLib; LatestRevisionNote: Re-released for DXP Platform.; LatestRevisionDate: 17-Jul-2002; Component Kind: Standard; Publisher: Altium Limited
TP100-TP103 = TP-Round-Yellow: Library Name: MY_TP.SchLib; Component Kind: Standard
TP104 TP105 = TP-Round-Black: Library Name: MY_TP.SchLib; Component Kind: Standard
U100-U103 = Dual OP Amp separte supplies: IC AUDIO AMP 2 CIRCUIT 8VSSOP; Manufacturer Part Number: OPA1678IDGKT; Manufacturer: Texas Instruments; Slew Rate: 9V/µs; Operating Supply Current: 2mA; Number of Circuits: 2; Input Offset Voltage (Vos): 500uV; Input Bias Current: 10pA; Gain Bandwidth Product: 16MHz; Value: OPA1678; Supplier Part Number: 296-46561-2-ND; Supplier: Digi-Key; Packaging: Tape and Reel; Number of Channels: 2; Mount: Surface Mount; Min Operating Temperature: -40°C; Max Operating Temperature: 85°C; Library Name: My_OpAmps.SchLib; Component Kind: Standard; Case/Package: VSSOP
U104 = CD4040BM96: IC COUNTER 12BIT CMOS 16SOIC; Manufacturer: ON Semiconductor; Vendor: Texas Instruments; Turn-On Delay Time: 3250ns; Quiescent Current: 20uA; Power Dissipation: 500mW; Number of Output Lines: 12; Number of Inputs: 1; Min Supply Voltage: 3V; Max Supply Voltage: 18V; Low Level Output Current: 8.8mA; High Level Output Current: -8.8mA; Frequency: 10MHz; Direction: Up,Unidirectional; Clock Edge Trigger Type: Negative Edge; Capacitance: 7.5pF; Built_By: EMA_UL_Team; Width: 4mm; Value: Value; Type: DEV; Supplier Part Number: MC14040BDR2GOSCT-ND; Supplier: Digi-Key; RefDes: RefDes; REACH SVHC: No SVHC; Radiation Hardening: No; Propagation Delay: 3250ns; Packaging: Tape and Reel; Package Quantity: 2500; Number of Pins: 16; Number of Input Lines: 1; Number of Elements: 1; Number of Circuits: 1; Number of Bits per Element: 12; Number of Bits: 12; Mount: Surface Mount; Min Operating Temperature: -55°C; Max Operating Temperature: 125°C; Manufacturer Part Number: MC14040BDR2G; Logic Function: Counter; Library Name: 4040.SchLib; Length: 10mm; Lead Free: Lead Free; Height: 1.5mm; Halogen Free: Halogen Free; Contact Plating: Tin,Matte; Component Kind: Standard; Case/Package: SOIC; Copyright: Copyright (C) 2018 Accelerated Designs. All rights reserved
U105 = CYN17: OPTOISO 5KV TRANS W/BASE 6SMD; Manufacturer: Vishay Lite-On; Rise Time: 10000ns; Reverse Breakdown Voltage: 6V; Power Dissipation: 250mW; Output Voltage: 70V; Output Current per Channel: 150mA; Number of Elements: 1; Max Input Current: 60mA; Forward Voltage: 1.45V; Current Transfer Ratio: 80%; Collector Emitter Saturation Voltage: 300mV; Supplier Part Number: 160-1309-5-ND; Supplier: Digi-Key; Packaging: Rail/Tube; Package Quantity: 65; Number of Pins: 6; Number of Circuits: 1; Number of Channels: 1; Mount: Surface Mount; Min Operating Temperature: -55°C; Max Power Dissipation: 250mW; Max Output Voltage: 70V; Max Operating Temperature: 100°C; Max Collector Current: 150mA; Manufacturer Part Number: CNY17-1S; Library Name: MY_Opto.SchLib; Lead Free: Lead Free; Forward Current: 60mA; Fall Time: 10000ns; Component Kind: Standard; Collector Emitter Voltage (VCEO): 70V; Case/Package: SMD/SMT
U106 = CD4028BM96: Decoder/Demultiplexer Single 4-to-10 16-Pin SOIC T/R; Manufacturer: ON Semiconductor; Vendor: Texas Instruments; Turn-On Delay Time: 600ns; Supply Type: Single,Dual; Quiescent Current: 20uA; Polarity: Non-Inverting; Number of Outputs: 10; Number of Inputs: 4; Number of Elements: 1; Number of Circuits: 3; Min Supply Voltage: 3V; Max Supply Voltage: 18V; Low Level Output Current: 4.2mA; Logic Function: Decoder,Demux,Demultiplexer; High Level Output Current: -4.2mA; Built_By: EMA_UL_Team; Width: 4mm; Value: Value; Type: DEV; Supplier Part Number: MC14028BDR2GOSCT-ND; Supplier: Digi-Key; RefDes: RefDes; REACH SVHC: No SVHC; Radiation Hardening: No; Propagation Delay: 600ns; Packaging: Tape and Reel; Package Quantity: 2500; Number of Pins: 16; Number of Output Lines: 10; Number of Input Lines: 4; Number of Bits: 3; Mount: Surface Mount; Min Operating Temperature: -55°C; Max Operating Temperature: 125°C; Manufacturer Part Number: MC14028BDR2G; Library Name: MY_4028.SchLib; Length: 10mm; Lead Free: Lead Free; Independent Circuits: 1; Height: 1.5mm; Halogen Free: Halogen Free; Component Kind: Standard; Case/Package: SOIC; Copyright: Copyright (C) 2018 Accelerated Designs. All rights reserved
U107 = TLV2371_5SOT23: OPA172IDCKT 10 MHz,10 V/s, 4.5V to 36V, SC-70; Manufacturer Part Number: OPA172IDBVR; Manufacturer: Texas Instruments; Voltage Gain: 130dB; Value: TLV271; Slew Rate: 10V/µs; Power Supply Rejection Ratio (PSRR): -120dB; Output Current per Channel: 75mA; Operating Supply Current: 1.6mA; Number of Circuits: 1; Min Dual Supply Voltage: 2.25V; Max Dual Supply Voltage: 18V; Input Offset Voltage (Vos): 200uV; Input Bias Current: 8pA; Gain Bandwidth Product: 10MHz; Common Mode Rejection Ratio: 90dB; Supplier Part Number: 296-40433-1-ND; Supplier: Digi-Key; Simulation: TLV2371; REACH SVHC: No SVHC; Packaging: Tape and Reel; Number of Pins: 5; Number of Channels: 1; Number of Amplifiers: 1; Mount: Surface Mount; Min Supply Voltage: 4.5V; Min Operating Temperature: -40°C; Max Supply Voltage: 36V; Max Operating Temperature: 125°C; Lead Free: Lead Free; Contact Plating: Gold; Component Kind: Standard; Case/Package: SOT-23-5; Bandwidth: 10MHz; Library Name: TI-OPAMPS.SchLib
S103 = 7wAY-sw: Library Name: MY_SWITCH.SchLib; Component Kind: Standard
